# Altium schematic sheet Sheet9.SchDoc
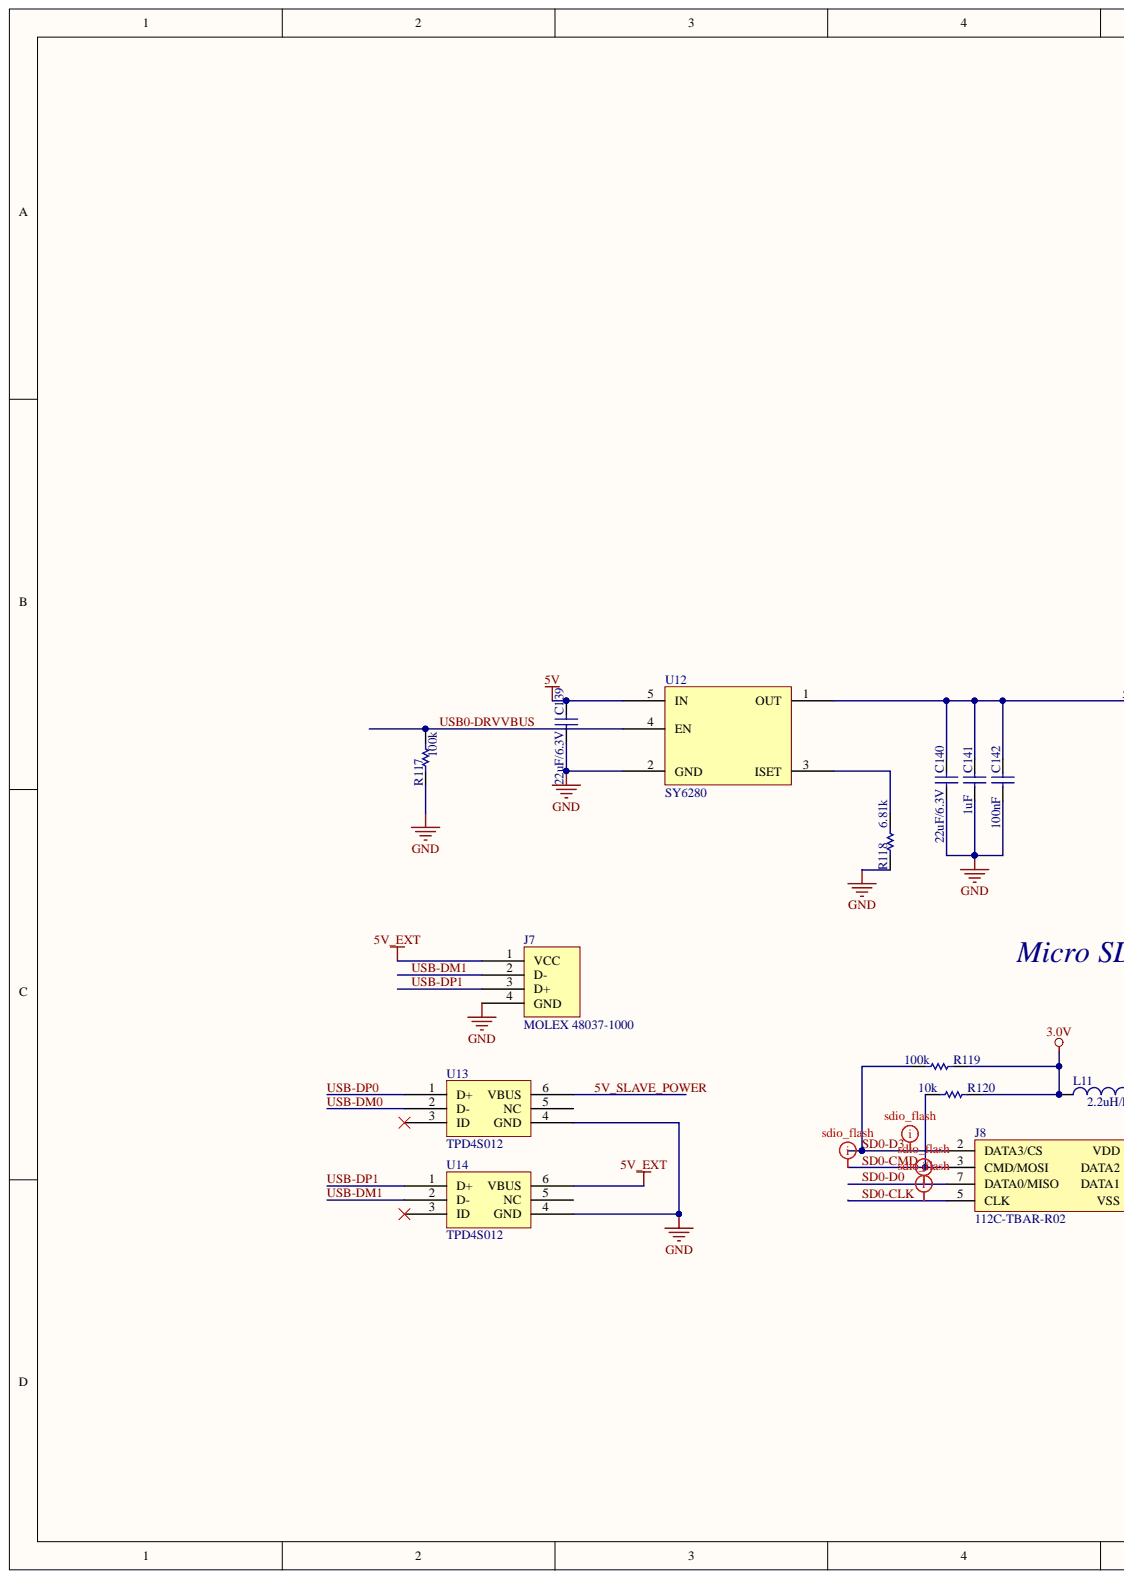
[diagram p0: Sheet9.SchDoc, left]
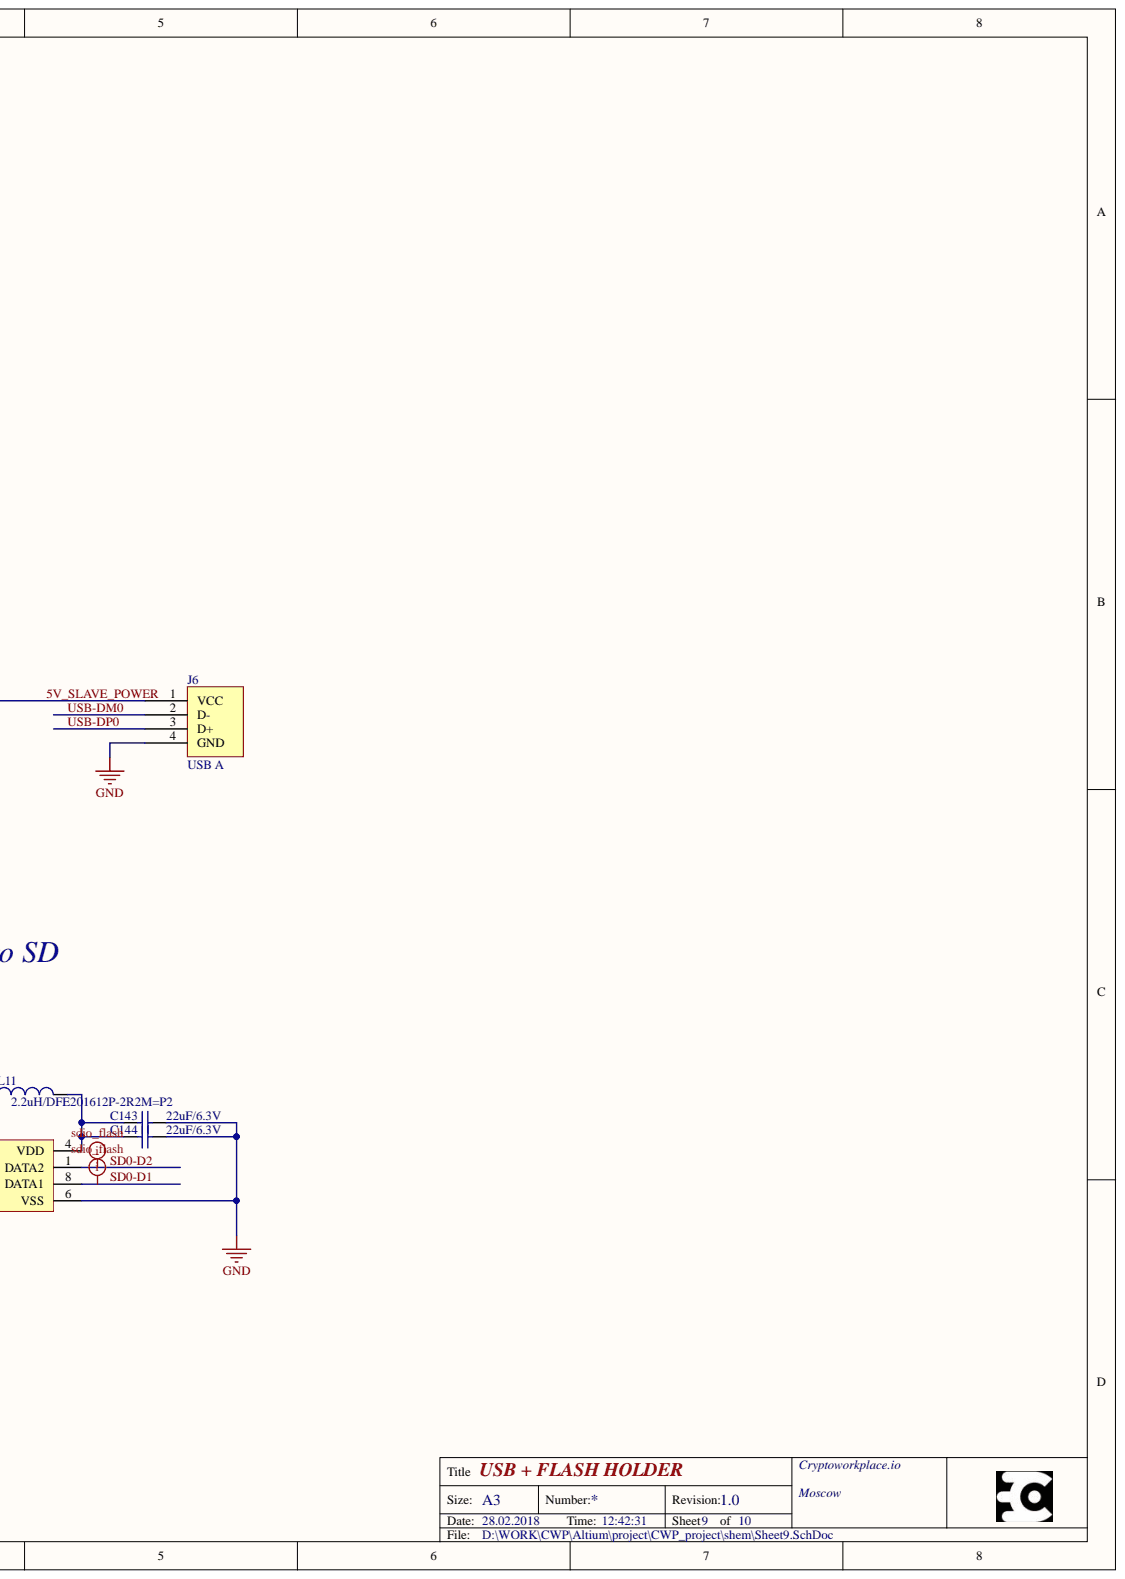
[diagram p1: Sheet9.SchDoc, right]

## Parts
C139  value 22uF/6.3V  [0603(CAPC1608X09N)]  (hidden comment: Cap)
C140  value 22uF/6.3V  [0603(CAPC1608X09N)]  (hidden comment: Cap)
C141  value 1uF  [0402(CAPC1005X06N)]  (hidden comment: 0402)
C142  value 100nF  [0402(CAPC1005X06N)]  (hidden comment: 0402)
C143  value 22uF/6.3V  [0603(CAPC1608X09N)]  (hidden comment: Cap)
C144  value 22uF/6.3V  [0603(CAPC1608X09N)]  (hidden comment: Cap)
J6  USB A  [48258-0002]
J7  MOLEX 48037-1000  [USB-A-PLUG]
J8  112C-TBAR-R02  [MOLEX_492250821_sd]
L11  value 2.2uH/DFE201612P-2R2M=P2  [0805(INDC2012X0N)]  (hidden comment: SWPA4018S1R5NT(1.5uH/3A/DCR<0.1R))
R117  value 100k  [0402(RESC1005X04N)]  (hidden comment: Res1)
R118  value 6.81k  [0402(RESC1005X04N)]  (hidden comment: Res1)
R119  value 100k  [0402(RESC1005X04N)]  (hidden comment: Res1)
R120  value 10k  [0402(RESC1005X04N)]  (hidden comment: Res1)
U12  SY6280  [SOT23-5]
U13  TPD4S012  [DRY (R-PUSON-N6)]
U14  TPD4S012  [DRY (R-PUSON-N6)]

## Nets
3.0V: L11.1 R119.1 R120.1
5V: C139.2 U12.5(IN)
5V_EXT: J7.1(VCC) U14.6(VBUS)
GND: C139.1 C140.1 C141.1 C142.1 C143.1 C144.1 J6.4(GND) J7.4(GND) J8.6(VSS) R117.1 R118.1 U12.2(GND) U13.4(GND) U14.4(GND)
5V_SLAVE_POWER: C140.2 C141.2 C142.2 J6.1(VCC) U12.1(OUT) U13.6(VBUS)
SD0-CLK: J8.5(CLK)
SD0-CMD: J8.3(CMD/MOSI) R120.2
SD0-D0: J8.7(DATA0/MISO)
SD0-D1: J8.8(DATA1)
SD0-D2: J8.1(DATA2)
SD0-D3: J8.2(DATA3/CS) R119.2
USB0-DRVVBUS: R117.2 U12.4(EN)
USB-DM0: J6.2(D-) U13.2(D-)
USB-DM1: J7.2(D-) U14.2(D-)
USB-DP0: J6.3(D+) U13.1(D+)
USB-DP1: J7.3(D+) U14.1(D+)
NetC143_2: C143.2 C144.2 J8.4(VDD) L11.2
NetR118_2: R118.2 U12.3(ISET)

Not connected: U13 3(ID) 5(NC); U14 3(ID) 5(NC)

## Library data of the parts
C139-C144 = Cap: Capacitor; Component Kind: Standard; LatestRevisionDate: 17-Jul-2002; LatestRevisionNote: Re-released for DXP Platform.; Library Name: Miscellaneous Devices.IntLib; PackageDescription: Radial Cap, Thru-Hole; 2 Leads; 0.3 in Pin Spacing; PackageReference: RAD-0.3; Published: 8-Jun-2000; Signal Integrity: Cap; Simulation: CAP; Publisher: Altium Limited
J7 = USB A: Component Kind: Standard; Description: MOLEX 48037-1000; Library Name: sockets.SchLib
J8 = 112C-TBAR-R02 (SD CARD HOLDER): Component Kind: Standard; Library Name: sockets.SchLib
L11 = Inductor: Code_IEC: 1005; Code_JEITA: 0402; Component Kind: Standard; Description: Inductor; LatestRevisionDate: 29-May-2009; LatestRevisionNote: IPC-7351 Footprint Added.; Library Name: Miscellaneous Devices.IntLib; PackageDescription: Chip Inductor; PackageReference: 0402-A; Published: 8-Jun-2000; Signal Integrity: Inductor; Simulation: INDUCTOR; Publisher: Altium Limited
R117-R120 = Res1: Resistor; Component Kind: Standard; LatestRevisionDate: 17-Jul-2002; LatestRevisionNote: Re-released for DXP Platform.; Library Name: Miscellaneous Devices.IntLib; PackageDescription: Axial Device, Thru-Hole; 2 Leads; 0.3 in Pin Spacing; PackageReference: AXIAL-0.3; Published: 8-Jun-2000; Signal Integrity: Res; Simulation: RESISTOR; Publisher: Altium Limited
U13 U14 = TPD4S012: 4-Channel ESD Solution for USB-HS/USB OTG/USB Charger Interface; Component Kind: Standard; Library Name: ti.SchLib
J6 = USB A: Component Kind: Standard; Description: USB A; Library Name: sockets.SchLib
U12 = SY6280: Component Kind: Standard; Description: SY6280; Library Name: SILERGY.SchLib
